# Altium schematic sheet 控制板.SchDoc(控制板)
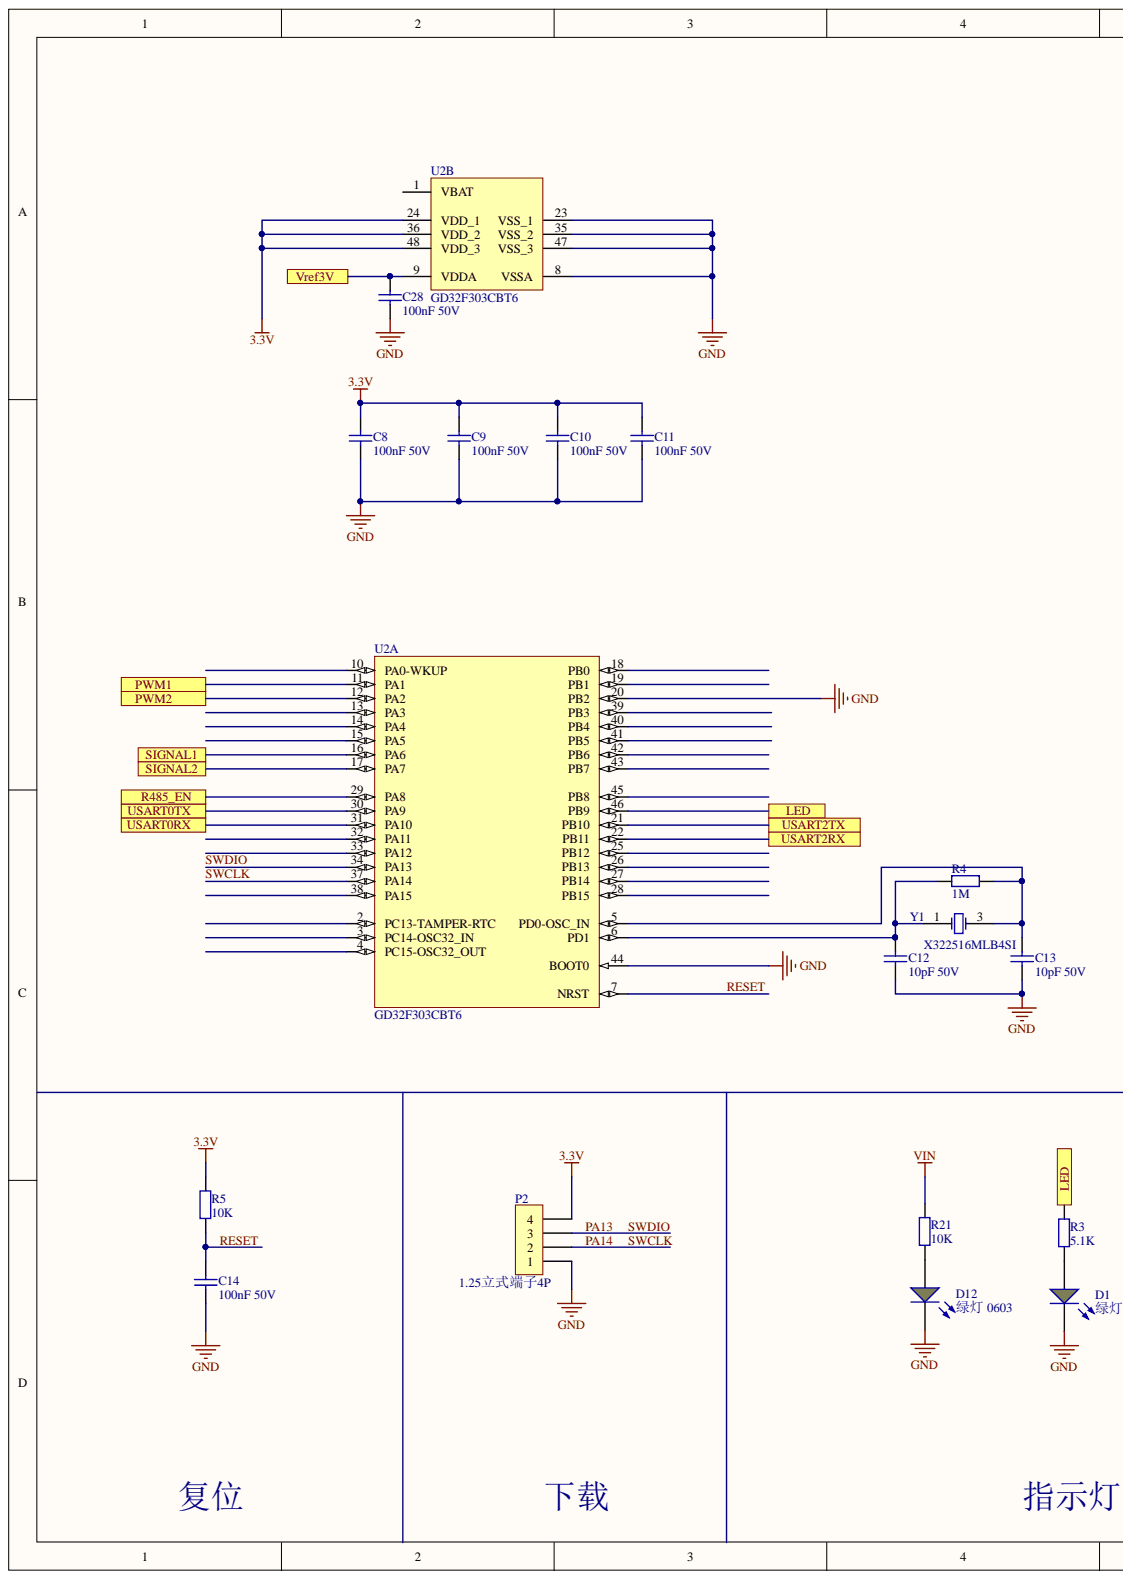
[diagram p0: 控制板.SchDoc, left]
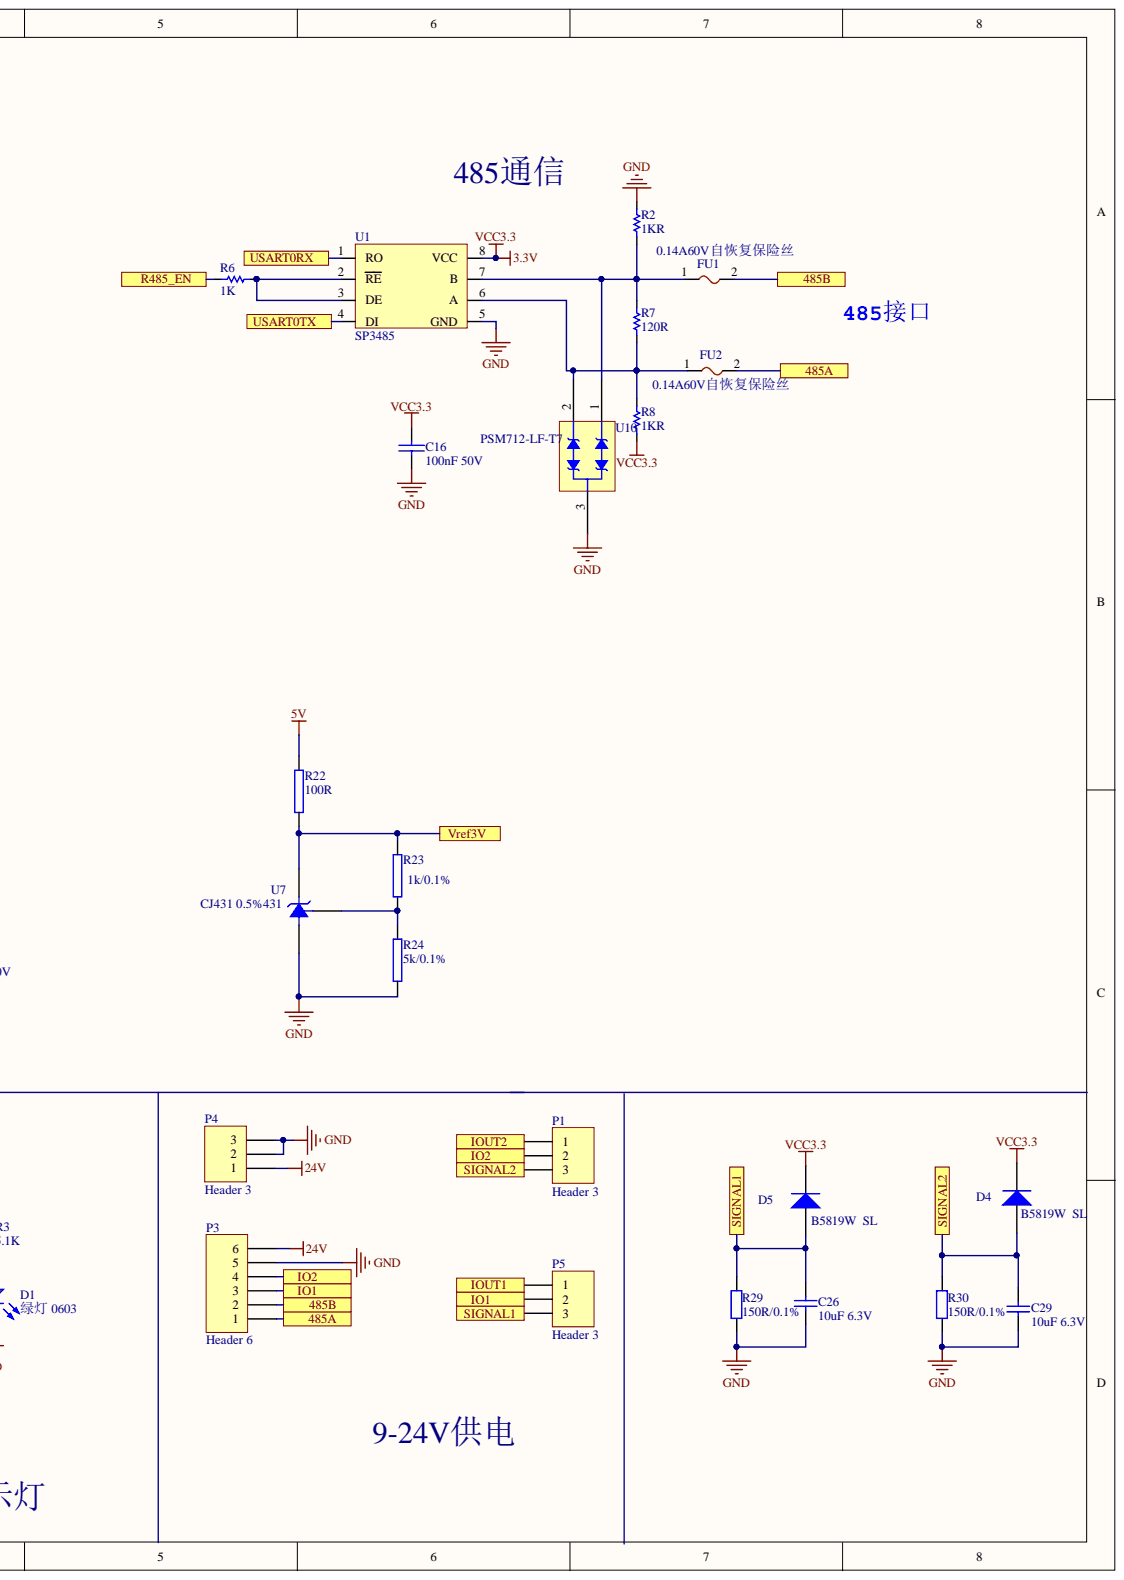
[diagram p1: 控制板.SchDoc, right]

## Parts
C8  100nF 50V  [0603_C]
C9  100nF 50V  [0603_C]
C10  100nF 50V  [0603_C]
C11  100nF 50V  [0603_C]
C12  10pF 50V  [0603_C]
C13  10pF 50V  [0603_C]
C14  100nF 50V  [0603_C]
C16  100nF 50V  [0603_C]
C26  10uF 6.3V  [0603_C]
C28  100nF 50V  [0603_C]
C29  10uF 6.3V  [0603_C]
D1  绿灯 0603  Package: 0603  [0805_LED_S1]
D4  B5819W  SL  [SOD-123]
D5  B5819W  SL  [SOD-123]
D12  绿灯 0603  Package: 0603  [0805_LED_S1]
FU1  0.14A60V自恢复保险丝  [1206_R]
FU2  0.14A60V自恢复保险丝  [1206_R]
P1  Header 3  [HDR1X3]
P2  1.25立式端子4P  [1.2.5/4PM]
P3  Header 6  [3.81-6P -90]
P4  Header 3  [DC5-2.0]
P5  Header 3  [HDR1X3]
R2  1KR  [0603_R]
R3  5.1K  [0805_R]
R4  1M  [0603_R]
R5  10K  [0603_R]
R6  1K  [0603_R]
R7  120R  [0603_R]
R8  1KR  [0603_R]
R21  10K  [0805_R]
R22  100R  [0603_R]
R23  1k/0.1%  [0603_R]
R24  5k/0.1%  [0603_R]
R29  150R/0.1%  [0805_R]
R30  150R/0.1%  [0805_R]
U1  SP3485  [SOIC-8_150mil]
U2  GD32F303CBT6  [LQFP-48_7x7x05P]  (parts A,B)
U7  CJ431 0.5%431  [SOT-23(SOT-23-3)]
U10  PSM712-LF-T7  [SOT-23(SOT-23-3)]
Y1  X322516MLB4SI  [SMD-3225_4P]

## Ports
485A, 485B, IO1, IO2, IOUT1, IOUT2, LED, PWM1, PWM2, R485_EN, SIGNAL1, SIGNAL2, USART0RX, USART0TX, USART2RX, USART2TX, Vref3V

## Nets
3.3V: C8.2 C9.2 C10.2 C11.2 C16.1 D4.2 D5.2 P2.4 R5.2 R8.1 U1.8(VCC) U2.24(VDD_1) U2.36(VDD_2) U2.48(VDD_3)
5V: R22.2
24V: P3.6 P4.1
GND: C8.1 C9.1 C10.1 C11.1 C12.1 C13.1 C14.1 C16.2 C26.1 C28.2 C29.1 D1.K D12.K P2.1 P3.5 P4.2 P4.3 R2.2 R24.1 R29.1 R30.1 U1.5(GND) U2.8(VSSA) U2.20(PB2) U2.23(VSS_1) U2.35(VSS_2) U2.44(BOOT0) U2.47(VSS_3) U7.3 U10.3
VIN: R21.2
485A: FU2.2 P3.1 ; port 485A
485B: FU1.2 P3.2 ; port 485B
IO1: P3.3 P5.2 ; port IO1
IO2: P1.2 P3.4 ; port IO2
IOUT1: P5.1 ; port IOUT1
IOUT2: P1.1 ; port IOUT2
LED: R3.2 U2.46(PB9) ; port LED
PA13: P2.3 U2.34(PA13)
PA14: P2.2 U2.37(PA14)
PWM1: U2.11(PA1) ; port PWM1
PWM2: U2.12(PA2) ; port PWM2
R485_EN: R6.2 U2.29(PA8) ; port R485_EN
RESET: C14.2 R5.1 U2.7(NRST)
SIGNAL1: C26.2 D5.1 P5.3 R29.2 U2.16(PA6) ; port SIGNAL1
SIGNAL2: C29.2 D4.1 P1.3 R30.2 U2.17(PA7) ; port SIGNAL2
USART0RX: U1.1(RO) U2.31(PA10) ; port USART0RX
USART0TX: U1.4(DI) U2.30(PA9) ; port USART0TX
USART2RX: U2.22(PB11) ; port USART2RX
USART2TX: U2.21(PB10) ; port USART2TX
Vref3V: C28.1 R22.1 R23.1 U2.9(VDDA) U7.2 ; port Vref3V
NetC12_2: C12.2 R4.1 U2.6(PD1) Y1.1
NetC13_2: C13.2 R4.2 U2.5(PD0-OSC_IN) Y1.3
NetD1_A: D1.A R3.1
NetD12_A: D12.A R21.1
NetFU1_1: FU1.1 R2.1 R7.1 U1.7(B) U10.1
NetFU2_1: FU2.1 R7.2 R8.2 U1.6(A) U10.2
NetR6_1: R6.1 U1.2(RE) U1.3(DE)
NetR23_2: R23.2 R24.2 U7.1
N00046:

Not connected: U2 1(VBAT) 2(PC13-TAMPER-RTC) 3(PC14-OSC32_IN) 4(PC15-OSC32_OUT) 10(PA0-WKUP) 13(PA3) 14(PA4) 15(PA5) 18(PB0) 19(PB1) 25(PB12) 26(PB13) 27(PB14) 28(PB15) 32(PA11) 33(PA12) 38(PA15) 39(PB3) 40(PB4) 41(PB5) 42(PB6) 43(PB7) 45(PB8)

## Library data of the parts
C8-C11 C14 C28 = 0402 100nF (104) 10% 16V: 贴片电容; SuppliersPartNumber: C1525; Supplier: LC; Package: 0402; Library Name: Cap.SchLib; ComponentLink1URL: http://www.szlcsc.com/product/details_1877.html; ComponentLink1Description: 供应商链接; Component Kind: Standard
C12 C13 = 0402 10pF (100) 5% 50V: 贴片电容; SuppliersPartNumber: C32949; Supplier: LC; Package: 0402; Library Name: Cap.SchLib; ComponentLink1URL: http://www.szlcsc.com/product/details_33914.html; ComponentLink1Description: 供应商链接; Component Kind: Standard
C16 = CAP: Component Kind: Standard; Library Name: wgh元件库.SCHLIB
C26 C29 = 0402 10uF (106) 20% 6.3V: 贴片电容; SuppliersPartNumber: C15525; Supplier: LC; Package: 0402; Library Name: Cap.SchLib; ComponentLink1URL: http://www.szlcsc.com/product/details_16204.html; ComponentLink1Description: 供应商链接; Component Kind: Standard
D1 D12 = 0603 绿灯: 发光二极管; SuppliersPartNumber: C72043; Supplier: LC; ComponentLink1URL: http://www.szlcsc.com/product/details_73152.html; ComponentLink1Description: 供应商链接; Component Kind: Standard; Library Name: wgh元件库.SCHLIB
D4 D5 = SOD-123 B5819W  SL: 肖特基二极管; SuppliersPartNumber: C8598; Supplier: LC; Package: SOD-123; Library Name: Diode.SchLib; ComponentLink1URL: http://www.szlcsc.com/product/details_9093.html; ComponentLink1Description: 供应商链接; Component Kind: Standard
FU1 FU2 = 自恢复保险丝: Component Kind: Standard; Library Name: wgh元件库.SCHLIB
P1 P4 P5 = Header 3: Header, 3-Pin; Library Name: Miscellaneous Connectors.IntLib; LatestRevisionNote: Re-released for DXP Platform.; LatestRevisionDate: 17-Jul-2002; Component Kind: Standard; Publisher: Altium Limited
P2 = CON4: Component Kind: Standard; Library Name: wgh元件库.SCHLIB
P3 = Header 6: Header, 6-Pin; Library Name: Miscellaneous Connectors.IntLib; LatestRevisionNote: Re-released for DXP Platform.; LatestRevisionDate: 17-Jul-2002; Component Kind: Standard; Publisher: Altium Limited
R2 R6-R8 = Res: Resistor; Value: 68.1K; Simulation: RESISTOR; Published: 8-Jun-2000; PackageReference: AXIAL-0.3; PackageDescription: Axial Device, Thru-Hole; 2 Leads; 0.3 in Pin Spacing; LatestRevisionNote: Re-released for DXP Platform.; LatestRevisionDate: 17-Jul-2002; Component Kind: Standard; Publisher: Altium Limited; Library Name: MAMA.SCHLIB
R3 R21 = 0402 1KΩ (1001) 1%: 贴片电阻; SuppliersPartNumber: C11702; Supplier: LC; Package: 0402; Library Name: RES.SchLib; ComponentLink1URL: http://www.szlcsc.com/product/details_12256.html; ComponentLink1Description: 供应商链接; Component Kind: Standard
R4 = 0402 1MΩ (1004) 1%: 贴片电阻; SuppliersPartNumber: C26083; Supplier: LC; Package: 0402; Library Name: RES.SchLib; ComponentLink1URL: http://www.szlcsc.com/product/details_26826.html; ComponentLink1Description: 供应商链接; Component Kind: Standard
R5 R29 R30 = 0603 20KΩ (2002) 1%: 贴片电阻; SuppliersPartNumber: C4184; Supplier: LC; Package: 0603; Library Name: RES.SchLib; ComponentLink1URL: http://www.szlcsc.com/product/details_4591.html; ComponentLink1Description: 供应商链接; Component Kind: Standard
R22-R24 = RES: Component Kind: Standard; Library Name: wgh元件库.SCHLIB
U2 = STM32F103CBT6: ARM; SuppliersPartNumber: C8304; Supplier: LC; Package: LQFP-48_7x7x05P; Library Name: MCU.SchLib; ComponentLink1URL: http://www.szlcsc.com/product/details_8796.html; ComponentLink1Description: 供应商链接; Component Kind: Standard
U7 = CJ431 0.5%431_[C3113]: 电压基准; SuppliersPartNumber: C3113; Supplier: LC; Package: SOT-23; Library Name: IC.SchLib; ComponentLink1URL: http://www.szlcsc.com/product/details_3500.html; ComponentLink1Description: 供应商链接; Component Kind: Standard
U10 = PSM712-LF-T7_[C32677]: TVS; SuppliersPartNumber: C32677; Supplier: LC; Package: SOT-23(SOT-23-3); Library Name: IC.SchLib; ComponentLink1URL: http://www.szlcsc.com/product/details_33642.html; ComponentLink1Description: 供应商链接; Component Kind: Standard
Y1 = SMD-3225_4P  16MHZ 9PF 10PPM: 16MHZ晶振; SuppliersPartNumber: C13738; Supplier: LC; Package: SMD-3225_4P; Library Name: CrystalOscillator.SchLib; ComponentLink1URL: http://www.szlcsc.com/product/details_14393.html; ComponentLink1Description: 供应商链接; Component Kind: Standard
U1 = SP3485: Component Kind: Standard; Library Name: wgh元件库.SCHLIB
